# Altium schematic sheet Sheet1.SchDoc(Sheet1) (continued)

## Library data of the parts
A-GND C-GND DVDD GATE2 RESET SENV2+ SENV- USB2+ VB2DET VBUS2 VBUS_C Vout = TEST_POINT: Manufacturer: Yageo; Manufacturer Part Number: RC0402FR-07100RL; Power Rating: 62.5mW; Resistance: 100R; Temperature Coefficient: 100ppm/°C; Tolerance: 1%; Voltage Rating: 50V; Case Code (Imperial): 0402; Case Code (Metric): 1005; Case/Package: 0402; Category: Chip SMD Resistors; Component Kind: Standard; ComponentLink1Description: https://datasheet.ciiva.com/7849/1784430-7849810.pdf?src-supplier=Element14; ComponentLink1URL: https://datasheet.ciiva.com/7849/1784430-7849810.pdf?src-supplier=Element14; ComponentLink2Description: https://datasheet.ciiva.com/11808/0900766b80c66297-11808765.pdf?src-supplier=RSComponents; ComponentLink2URL: https://datasheet.ciiva.com/11808/0900766b80c66297-11808765.pdf?src-supplier=RSComponents; ComponentLink3Description: https://datasheet.ciiva.com/12667/pyu-rc0402-51-rohs-l-6-r-12667957.pdf?src-supplier=Mouser; ComponentLink3URL: https://datasheet.ciiva.com/12667/pyu-rc0402-51-rohs-l-6-r-12667957.pdf?src-supplier=Mouser; ComponentLink4Description: https://datasheet.ciiva.com/16740/pyu-rc0402-51-rohs-l-6-r-16740566.pdf?src-supplier=Future+Electronics; ComponentLink4URL: https://datasheet.ciiva.com/16740/pyu-rc0402-51-rohs-l-6-r-16740566.pdf?src-supplier=Future+Electronics; ComponentLink5Description: https://datasheet.ciiva.com/15189/getdatasheetpartid-329466-15189335.pdf?src-supplier=Verical; ComponentLink5URL: https://datasheet.ciiva.com/15189/getdatasheetpartid-329466-15189335.pdf?src-supplier=Verical; ComponentLink6Description: https://datasheet.ciiva.com/22747/1018594-22747511.pdf?src-supplier=Element14; ComponentLink6URL: https://datasheet.ciiva.com/22747/1018594-22747511.pdf?src-supplier=Element14; ComponentLink7Description: https://datasheet.ciiva.com/28367/1018594-28367226.pdf?src-supplier=Element14; ComponentLink7URL: https://datasheet.ciiva.com/28367/1018594-28367226.pdf?src-supplier=Element14; Composition: Thick Film; Depth: 0.5mm; Height: 0.32mm; Lead Free: Lead Free; Length: 1mm; Library Name: MyLibrary.SchLib; Max Operating Temperature: 155°C; Military Standard: Not; Min Operating Temperature: -55°C; Number of Pins: 2; Packaging: Tape and Reel; Pricing 1: 1=0.10000, 10=0.01500, 100=0.00620, 1000=0.00278, 2500=0.00242, 5000=0.00200 (USD); REACH SVHC: No SVHC; RoHS: Yes; Stock 1: 14920159; Supplier 1: Digi-Key; Supplier Part Number 1: 311-100LRCT-ND; Termination: SMD/SMT; Voltage Rating (DC): 50V; Width: 0.5mm
C1 C13 = CC0805CRNPO9BN1R0: CAP CER 1PF 50V C0G/NP0 0402; Manufacturer: Yageo; Dielectric: C0G; Material: Ceramic; Tolerance: 25%; Capacitance: 1pF; Case Code (Imperial): 0805; Case Code (Metric): 2012; Case/Package: 0805; Category: Ceramic Capacitors; Component Kind: Standard; ComponentLink1Description: https://datasheet.ciiva.com/144/mlccorderingcode-144977.pdf?src-supplier=Digi-Key; ComponentLink1URL: https://datasheet.ciiva.com/144/mlccorderingcode-144977.pdf?src-supplier=Digi-Key; ComponentLink2Description: https://datasheet.ciiva.com/144/mlccorderingcode-144978.pdf?src-supplier=Digi-Key; ComponentLink2URL: https://datasheet.ciiva.com/144/mlccorderingcode-144978.pdf?src-supplier=Digi-Key; ComponentLink3Description: https://datasheet.ciiva.com/144/mlccorderingcode-144979.pdf?src-supplier=Digi-Key; ComponentLink3URL: https://datasheet.ciiva.com/144/mlccorderingcode-144979.pdf?src-supplier=Digi-Key; ComponentLink4Description: https://datasheet.ciiva.com/11465/upy-gp-np0-16v-to-50v-8-11465247.pdf?src-supplier=Avnet; ComponentLink4URL: https://datasheet.ciiva.com/11465/upy-gp-np0-16v-to-50v-8-11465247.pdf?src-supplier=Avnet; Composition: Ceramic; Depth: 1.25mm; Height: 0.6mm; Lead Free: Lead Free; Length: 2mm; Library Name: MyLibrary.SchLib; Manufacturer Part Number: CC0805CRNPO9BN1R0; Max Operating Temperature: 125°C; Min Operating Temperature: -55°C; Mount: Surface Mount; Package Quantity: 1; Packaging: Tape and Reel; Pricing 1: 1=0.13000, 10=0.09300, 50=0.05260, 100=0.04360, 500=0.03056, 1000=0.02530 (USD); Radiation Hardening: No; RoHS: Yes; Stock 1: 10394; Supplier 1: Digi-Key; Supplier Part Number 1: 490-3199-1-ND; Termination: SMD/SMT; Thickness: 0.024inch; Voltage Rating: 50V; Voltage Rating (DC): 50V; Width: 0.049inch
C2 C14 C15 C23 C30 = C2012X7R1H104K085AA: CAP CER 0.1UF 16V Y5V 0402; Manufacturer: TDK Corporation; .Checked PCB: RF; .Creator: MM; Capacitance: 0.1µF; Temperature Coefficient: X7R; Tolerance: ±10%; Voltage - Rated: 50V; Applications: General Purpose; Category: Capacitors; Component Kind: Standard; ComponentLink1Description: http://product.tdk.com/en/catalog/datasheets/mlcc_commercial_general_en.pdf; ComponentLink1URL: http://product.tdk.com/en/catalog/datasheets/mlcc_commercial_general_en.pdf; Features: -; Height - Seated (Max): -; Lead Spacing: -; Lead Style: -; Library Name: MyLibrary.SchLib; Manufacturer Part Number: C2012X7R1H104K085AA; Mounting Type: Surface Mount, MLCC; Operating Temperature: -55°C ~ 125°C; Package / Case: 0805 (2012 Metric); Packaging: Cut Tape (CT); Pricing 1: 1=0.1, 10=0.066, 100=0.0303, 250=0.02476, 500=0.02118, 1000=0.0165 (USD); Ratings: -; RoHS: RoHS Compliant; Size / Dimension: 0.079" L x 0.049" W (2.00mm x 1.25mm); Stock 1: 818066; Supplier 1: Digi-Key; Supplier Part Number 1: 478-1138-1-ND; Thickness (Max): 0.037" (0.95mm); .Checked SCH: RF
C3-C9 C29 C32 = GRM21BR61A226ME44L: CAP CER 22UF 6.3V X5R 0402; Manufacturer: Murata; Dielectric Material: Ceramic; Dissipation Factor: 0.035%; Tolerance: 20%; Voltage: 10V; Capacitance: 22uF; Case Code (Imperial): 0805; Case Code (Metric): 2012; Case/Package: 0805; Category: Ceramic Capacitors; Component Kind: Standard; ComponentLink1Description: http://www.mouser.com/ds/2/281/c02e-2905.pdf?src-supplier=Mouser; ComponentLink1URL: http://www.mouser.com/ds/2/281/c02e-2905.pdf?src-supplier=Mouser; ComponentLink2Description: http://search.murata.co.jp/Ceramy/image/img/A01X/G101/ENG/GRM21BR61A226ME44-01.pdf?src-supplier=Digi-Key; ComponentLink2URL: http://search.murata.co.jp/Ceramy/image/img/A01X/G101/ENG/GRM21BR61A226ME44-01.pdf?src-supplier=Digi-Key; ComponentLink3Description: http://search.murata.co.jp/Ceramy/image/img/A01X/partnumbering_e_01.pdf?src-supplier=Digi-Key; ComponentLink3URL: http://search.murata.co.jp/Ceramy/image/img/A01X/partnumbering_e_01.pdf?src-supplier=Digi-Key; Composition: Ceramic; Depth: 1.25mm; Dielectric: X5R; Height: 1.25mm; Lead Free: Lead Free; Length: 2mm; Library Name: MyLibrary.SchLib; Manufacturer Part Number: GRM21BR61A226ME44L; Max Operating Temperature: 85°C; Min Operating Temperature: -55°C; Mount: Surface Mount; Package Quantity: 3000; Packaging: Tape and Reel; Pricing 1: 1=0.58000, 10=0.40900, 50=0.30900, 100=0.26920, 500=0.19940, 1000=0.16950 (USD); RoHS: Yes; Stock 1: 27473; Supplier 1: Digi-Key; Supplier Part Number 1: 1276-7090-1-ND; Termination: SMD/SMT; Thickness: 0.057inch; Voltage Rating: 10V; Voltage Rating (DC): 10V; Weight: 0.000335oz; Width: 1.25mm
C10 C16 C19 C21 C24-C26 = C2012X5R1E105K125AA: CAP CER 1UF 6.3V X5R 0402; Manufacturer: TDK Corporation; .Checked PCB: RF; .Creator: MM; Capacitance: 1µF; Tolerance: ±10%; Voltage - Rated: 25V; Applications: General Purpose; Category: Capacitors; Component Kind: Standard; ComponentLink1Description: http://product.tdk.com/en/catalog/datasheets/mlcc_commercial_general_en.pdf; ComponentLink1URL: http://product.tdk.com/en/catalog/datasheets/mlcc_commercial_general_en.pdf; Features: -; Height - Seated (Max): -; Lead Spacing: -; Lead Style: -; Library Name: MyLibrary.SchLib; Manufacturer Part Number: C2012X5R1E105K125AA; Mounting Type: Surface Mount, MLCC; Operating Temperature: -55°C ~ 85°C; Package / Case: 0805 (2012 Metric); Packaging: Cut Tape (CT); Pricing 1: 1=0.16, 10=0.112, 100=0.0533, 250=0.04488, 500=0.03758, 1000=0.03025 (USD); Ratings: -; RoHS: RoHS Compliant; Size / Dimension: 0.079" L x 0.049" W (2.00mm x 1.25mm); Stock 1: 57052; Supplier 1: Digi-Key; Supplier Part Number 1: 478-1249-1-ND; Temperature Coefficient: X5R; Thickness (Max): 0.057" (1.45mm); .Checked SCH: RF
C11 C12 = CC0805MKX5R5BB476: CAP CER 47UF 4V X5R 0603; Manufacturer: Yageo; Tolerance: 20%; Voltage Rating: 6.3V; Capacitance: 47uF; Case Code (Imperial): 0805; Case Code (Metric): 2012; Case/Package: 0805; Category: Ceramic Capacitors; Component Kind: Standard; ComponentLink1Description: http://components.arrow.com/part/dataview/0323_04166612?src-supplier=Arrow+Europe; ComponentLink1URL: http://components.arrow.com/part/dataview/0323_04166612?src-supplier=Arrow+Europe; ComponentLink2Description: http://www.mouser.com/ds/2/447/UPY-GPHC_X5R_4V-to-50V_25-1131599.pdf?src-supplier=Mouser; ComponentLink2URL: http://www.mouser.com/ds/2/447/UPY-GPHC_X5R_4V-to-50V_25-1131599.pdf?src-supplier=Mouser; ComponentLink3Description: http://www.yageo.com/documents/recent/UPY-GPHC_X5R_4V-to-50V_19.pdf?src-supplier=Avnet; ComponentLink3URL: http://www.yageo.com/documents/recent/UPY-GPHC_X5R_4V-to-50V_19.pdf?src-supplier=Avnet; ComponentLink4Description: http://www.yageo.com/documents/recent/UPY-GPHC_X5R_4V-to-50V_25.pdf?src-supplier=Digi-Key; ComponentLink4URL: http://www.yageo.com/documents/recent/UPY-GPHC_X5R_4V-to-50V_25.pdf?src-supplier=Digi-Key; Composition: Ceramic; Depth: 1.25mm; Dielectric: X5R; Halogen Free: Halogen Free; Lead Free: Lead Free; Length: 2mm; Library Name: MyLibrary.SchLib; Manufacturer Part Number: CC0805MKX5R5BB476; Max Operating Temperature: 85°C; Min Operating Temperature: -55°C; Mount: Surface Mount; Packaging: Tape and Reel; Pricing 1: 1=0.48000, 10=0.33700, 50=0.23560, 100=0.20190, 500=0.15144, 1000=0.12789 (USD); Radiation Hardening: No; RoHS: Yes; Stock 1: 59203; Supplier 1: Digi-Key; Supplier Part Number 1: 490-13246-1-ND; Termination: SMD/SMT; Thickness: 0.057inch; Voltage Rating (DC): 6.3V; Weight: 0.000194oz; Width: 0.049inch
C17 C18 = CC0805KRX7R9BB391: CAP CER 390PF 50V X7R 0402; Manufacturer: Yageo; Dissipation Factor: 2.5%; Insulation Resistance: 10GR; Tolerance: 10%; Capacitance: 390pF; Case Code (Imperial): 0805; Case Code (Metric): 2012; Case/Package: 0805; Category: Ceramic Capacitors; Component Kind: Standard; ComponentLink1Description: https://datasheet.ciiva.com/26918/getdatasheetpartid-403704-26918335.pdf?src-supplier=Verical; ComponentLink1URL: https://datasheet.ciiva.com/26918/getdatasheetpartid-403704-26918335.pdf?src-supplier=Verical; ComponentLink2Description: https://datasheet.ciiva.com/13264/yageo-486313-494411-13264661.pdf?src-supplier=Mouser; ComponentLink2URL: https://datasheet.ciiva.com/13264/yageo-486313-494411-13264661.pdf?src-supplier=Mouser; ComponentLink3Description: https://datasheet.ciiva.com/160/mlccorderingcode-160730.pdf?src-supplier=Digi-Key; ComponentLink3URL: https://datasheet.ciiva.com/160/mlccorderingcode-160730.pdf?src-supplier=Digi-Key; ComponentLink4Description: https://datasheet.ciiva.com/11465/upy-gphc-x7r-6-3v-to-50v-8-11465738.pdf?src-supplier=Avnet; ComponentLink4URL: https://datasheet.ciiva.com/11465/upy-gphc-x7r-6-3v-to-50v-8-11465738.pdf?src-supplier=Avnet; ComponentLink5Description: https://datasheet.ciiva.com/160/mlccorderingcode-160731.pdf?src-supplier=Digi-Key; ComponentLink5URL: https://datasheet.ciiva.com/160/mlccorderingcode-160731.pdf?src-supplier=Digi-Key; ComponentLink6Description: https://datasheet.ciiva.com/160/mlccorderingcode-160732.pdf?src-supplier=Digi-Key; ComponentLink6URL: https://datasheet.ciiva.com/160/mlccorderingcode-160732.pdf?src-supplier=Digi-Key; ComponentLink7Description: https://datasheet.ciiva.com/17436/upy-gphc-x7r-6-3v-to-50v-8-17436447.pdf?src-supplier=Avnet+Europe; ComponentLink7URL: https://datasheet.ciiva.com/17436/upy-gphc-x7r-6-3v-to-50v-8-17436447.pdf?src-supplier=Avnet+Europe; Composition: Ceramic; Depth: 1.25mm; Dielectric: X7R; Halogen Free: Halogen Free; Height: 0.6mm; Lead Free: Lead Free; Length: 2mm; Library Name: MyLibrary.SchLib; Manufacturer Part Number: CC0805KRX7R9BB391; Max Operating Temperature: 125°C; Min Operating Temperature: -55°C; Mount: Surface Mount; Package Quantity: 1; Packaging: Tape and Reel; Pricing 1: 1=0.13000, 10=0.09000, 50=0.05120, 100=0.04240, 500=0.02972, 1000=0.02461 (USD); Radiation Hardening: No; RoHS: Yes; Stock 1: 64908; Supplier 1: Digi-Key; Supplier Part Number 1: 311-1724-1-ND; Termination: SMD/SMT; Thickness: 0.024inch; Voltage Rating: 50V; Voltage Rating (DC): 50V; Weight: 0.000194oz; Width: 1.25mm
C20 C31 C34 = CC0201KRX5R8BB102: CAP CER 1000PF 16V X7R 0402; Manufacturer: Yageo; Capacitance: 1nF; Dielectric: X5R; Tolerance: 10%; Voltage Rating: 25V; Case/Package: 0201; Category: Ceramic Capacitors; Component Kind: Standard; ComponentLink1Description: http://www.yageo.com/exep/pages/download/literatures/ProductSelectionGuide%202014_Final_2.pdf?src-supplier=Digi-Key; ComponentLink1URL: http://www.yageo.com/exep/pages/download/literatures/ProductSelectionGuide%202014_Final_2.pdf?src-supplier=Digi-Key; ComponentLink2Description: http://www.yageo.com/documents/recent/UPY-GPHC_X5R_4V-to-50V_25.pdf?src-supplier=Digi-Key; ComponentLink2URL: http://www.yageo.com/documents/recent/UPY-GPHC_X5R_4V-to-50V_25.pdf?src-supplier=Digi-Key; Halogen Free: Halogen Free; Lead Free: Lead Free; Length: 0.024inch; Library Name: MyLibrary.SchLib; Manufacturer Part Number: CC0201KRX5R8BB102; Max Operating Temperature: 85°C; Min Operating Temperature: -55°C; Mount: Surface Mount; Packaging: Tape and Reel; Pricing 1: 1=0.10000, 10=0.01500, 50=0.00800, 100=0.00660, 500=0.00470, 1000=0.00369, 2500=0.00336, 5000=0.00309 (USD); RoHS: Yes; Stock 1: 54569; Supplier 1: Digi-Key; Supplier Part Number 1: 311-1352-1-ND; Thickness: 0.013inch; Voltage Rating (DC): 25V; Width: 0.012inch
C22 = C0805C223K5RACTU: CAP CER 0.22UF 6.3V X5R 0402; Manufacturer: KEMET; Capacitance: 22nF; Dielectric: X7R; Dielectric Material: Ceramic; Tolerance: 10%; Voltage: 50V; Case/Package: 0805; Category: Ceramic Capacitors; Component Kind: Standard; ComponentLink1Description: https://datasheet.ciiva.com/18193/getdatasheetpartid-385695-18193669.pdf?src-supplier=Verical; ComponentLink1URL: https://datasheet.ciiva.com/18193/getdatasheetpartid-385695-18193669.pdf?src-supplier=Verical; Depth: 1.25mm; Height: 0.78mm; Length: 2mm; Library Name: MyLibrary.SchLib; Manufacturer Part Number: C0805C223K5RACTU; Max Operating Temperature: 125°C; Min Operating Temperature: -55°C; Mount: Surface Mount; Packaging: Tape and Reel; Pricing 1: 1=0.10000, 10=0.05700, 50=0.03120, 100=0.02550, 500=0.01822, 1000=0.01431 (USD); Radiation Hardening: No; RoHS: Yes; Stock 1: 230380; Supplier 1: Digi-Key; Supplier Part Number 1: 490-3252-1-ND; Voltage Rating (DC): 50V
C27 C28 = C0603C103M5RACTU: CAP CER 0.01UF 16V X7R 0402; Manufacturer: KEMET; Capacitance: 10nF; Dielectric Material: Ceramic; Dissipation Factor: 2.5%; Tolerance: 20%; Voltage Rating: 50V; Case Code (Imperial): 0603; Case Code (Metric): 1608; Case/Package: 0603; Category: Ceramic Capacitors; Component Kind: Standard; ComponentLink1Description: https://datasheet.ciiva.com/7823/kem-c1002-x7r-smd-7823979.pdf?src-supplier=Element14; ComponentLink1URL: https://datasheet.ciiva.com/7823/kem-c1002-x7r-smd-7823979.pdf?src-supplier=Element14; ComponentLink2Description: https://datasheet.ciiva.com/7823/kem-c1002-x7r-smd-7823980.pdf?src-supplier=Element14; ComponentLink2URL: https://datasheet.ciiva.com/7823/kem-c1002-x7r-smd-7823980.pdf?src-supplier=Element14; Depth: 0.8mm; Dielectric: X7R; Height: 0.8mm; Lead Pitch: 0.7mm; Length: 1.6mm; Library Name: MyLibrary.SchLib; Manufacturer Part Number: C0603C103M5RACTU; Max Operating Temperature: 125°C; Min Operating Temperature: -55°C; Mount: Surface Mount,PCB; Number of Pins: 2; Package Quantity: 1; Packaging: Tape and Reel; Pricing 1: 1=0.10000, 10=0.03300, 50=0.01820, 100=0.01480, 500=0.01058, 1000=0.00832 (USD); Radiation Hardening: No; REACH SVHC: No SVHC; RoHS: Yes; Stock 1: 479692; Supplier 1: Digi-Key; Supplier Part Number 1: 399-17617-1-ND; Termination: SMD/SMT; Thickness: 0.034inch; Voltage Rating (DC): 50V; Width: 0.8mm
C33 = C2012X5R1C106M: CAP CER 10UF 4V X5R 0402; Manufacturer: TDK Corporation; Manufacturer Part Number: C2012X5R1C106M/1.25; .Checked PCB: used; Capacitance: 10µF; Tolerance: ±20%; .Checked SCH: used; Applications: General Purpose; Category: Capacitors; Component Kind: Standard; ComponentLink1Description: http://www.tdk.com/pdf/general_B11.pdf; ComponentLink1URL: http://www.tdk.com/pdf/general_B11.pdf; Features: -; Height - Seated (Max): -; Lead Spacing: -; Lead Style: -; Library Name: MyLibrary.SchLib; Mounting Type: Surface Mount, MLCC; Operating Temperature: -55°C ~ 85°C; Package / Case: 0805 (2012 Metric); Packaging: Cut Tape (CT); Pricing 1: 1=0.45, 10=0.357, 100=0.215, 250=0.16124, 500=0.1376, 1000=0.1075 (USD); Ratings: -; RoHS: RoHS Compliant; Size / Dimension: 0.079" L x 0.049" W (2.00mm x 1.25mm); Stock 1: 66161; Supplier 1: Digi-Key; Supplier Part Number 1: 490-10693-1-ND; Temperature Coefficient: X5R; Thickness (Max): 0.039" (1.00mm)
D1 D2 = SZMM5Z5V1T1G: DIODE ZENER Single 5.1V 6% 200mW 2-Pin SOD-523 T/R; Manufacturer: ON Semiconductor; ESD Protection: Yes; Forward Voltage: 900mV; Impedance: 60R; Max Reverse Leakage Current: 2uA; Test Current: 5mA; Case/Package: SOD-523-2; Category: Zener Diodes; Component Kind: Standard; ComponentLink1Description: https://datasheet.ciiva.com/16492/mm5z2v4t1-d-16492709.pdf?src-supplier=Future+Electronics; ComponentLink1URL: https://datasheet.ciiva.com/16492/mm5z2v4t1-d-16492709.pdf?src-supplier=Future+Electronics; ComponentLink2Description: https://datasheet.ciiva.com/10638/mm5z2v4t1-d-10638122.pdf?src-supplier=Avnet; ComponentLink2URL: https://datasheet.ciiva.com/10638/mm5z2v4t1-d-10638122.pdf?src-supplier=Avnet; Contact Plating: Tin,Matte; Element Configuration: Single; Height: 0.5mm; Lead Free: Lead Free; Length: 1.3mm; Library Name: MyLibrary.SchLib; Manufacturer Part Number: SZMM5Z5V1T1G; Max Operating Temperature: 150°C; Max Power Dissipation: 200mW; Min Operating Temperature: -65°C; Number of Pins: 2; Package Quantity: 3000; Packaging: Tape and Reel; Peak Reverse Current: 2uA; Power Dissipation: 200mW; Pricing 1: 1=0.38000, 10=0.24500, 100=0.13650, 500=0.09100, 1000=0.06300 (USD); Radiation Hardening: No; RoHS: Yes; Stock 1: 1144; Supplier 1: Digi-Key; Supplier Part Number 1: SZMM5Z5V1T1GOSCT-ND; Tolerance: 6%; Width: 0.9mm; Working Voltage: 5.1V; Zener Voltage: 5.1V
D3 = BAT54CLT1G: DIODE SCHOTTKY 30V 0.2A 3-Pin SOT-23 T/R; Manufacturer: ON Semiconductor; Average Rectified Current: 200mA; Capacitance: 10pF; Element Configuration: Common Cathode; Forward Voltage: 800mV; Max Forward Surge Current (Ifsm): 600mA; Max Power Dissipation: 225mW; Max Reverse Leakage Current: 2uA; Recovery Time: 5ns; Case/Package: SOT-23-3; Category: Schottky Diodes; Component Kind: Standard; ComponentLink10Description: https://datasheet.ciiva.com/28655/bat54clt1-d-28655787.pdf?src-supplier=Element14; ComponentLink10URL: https://datasheet.ciiva.com/28655/bat54clt1-d-28655787.pdf?src-supplier=Element14; ComponentLink11Description: https://datasheet.ciiva.com/8294/bat54clt1-d-8294495.pdf?src-supplier=Avnet; ComponentLink11URL: https://datasheet.ciiva.com/8294/bat54clt1-d-8294495.pdf?src-supplier=Avnet; ComponentLink1Description: https://datasheet.ciiva.com/1240/bat54clt1-d-1240707.pdf?src-supplier=Newark; ComponentLink1URL: https://datasheet.ciiva.com/1240/bat54clt1-d-1240707.pdf?src-supplier=Newark; ComponentLink2Description: https://datasheet.ciiva.com/34301/885769-34301654.pdf?src-supplier=Jameco; ComponentLink2URL: https://datasheet.ciiva.com/34301/885769-34301654.pdf?src-supplier=Jameco; ComponentLink3Description: https://datasheet.ciiva.com/30479/0900766b8121b932-30479128.pdf?src-supplier=RSComponents; ComponentLink3URL: https://datasheet.ciiva.com/30479/0900766b8121b932-30479128.pdf?src-supplier=RSComponents; ComponentLink4Description: https://datasheet.ciiva.com/15144/getdatasheetpartid-98336-15144809.pdf?src-supplier=Verical; ComponentLink4URL: https://datasheet.ciiva.com/15144/getdatasheetpartid-98336-15144809.pdf?src-supplier=Verical; ComponentLink5Description: https://datasheet.ciiva.com/22659/1918740-22659014.pdf?src-supplier=Element14; ComponentLink5URL: https://datasheet.ciiva.com/22659/1918740-22659014.pdf?src-supplier=Element14; ComponentLink6Description: https://datasheet.ciiva.com/1180/bat54clt1-d-1180247.pdf?src-supplier=Element14; ComponentLink6URL: https://datasheet.ciiva.com/1180/bat54clt1-d-1180247.pdf?src-supplier=Element14; ComponentLink7Description: https://datasheet.ciiva.com/1180/bat54clt1-d-1180248.pdf?src-supplier=Element14; ComponentLink7URL: https://datasheet.ciiva.com/1180/bat54clt1-d-1180248.pdf?src-supplier=Element14; ComponentLink8Description: https://datasheet.ciiva.com/16205/bat54clt1-d-16205033.pdf?src-supplier=Future+Electronics; ComponentLink8URL: https://datasheet.ciiva.com/16205/bat54clt1-d-16205033.pdf?src-supplier=Future+Electronics; ComponentLink9Description: https://datasheet.ciiva.com/905/bat54clt1-d-905835.pdf?src-supplier=Digi-Key; ComponentLink9URL: https://datasheet.ciiva.com/905/bat54clt1-d-905835.pdf?src-supplier=Digi-Key; Contact Plating: Tin,Matte; Current Rating: 200mA; Forward Current: 200mA; Halogen Free: Halogen Free; Height: 1.01mm; Lead Free: Lead Free; Length: 3.04mm; Library Name: MyLibrary.SchLib; Manufacturer Part Number: BAT54CLT1G; Max Operating Temperature: 125°C; Max Repetitive Reverse Voltage (Vrrm): 30V; Max Reverse Voltage (DC): 30V; Max Surge Current: 600mA; Min Operating Temperature: -55°C; Number of Pins: 3; Package Quantity: 3000; Packaging: Tape and Reel; Peak Non-Repetitive Surge Current: 600mA; Peak Reverse Current: 2uA; Power Dissipation: 225mW; Pricing 1: 1=0.13000, 10=0.11800, 100=0.05550, 500=0.03290, 1000=0.02162 (USD); Radiation Hardening: No; REACH SVHC: No SVHC; Reverse Recovery Time: 5ns; RoHS: Yes; Stock 1: 292991; Supplier 1: Digi-Key; Supplier Part Number 1: BAT54CLT1GOSCT-ND; Voltage Rating (DC): 30V; Width: 1.4mm
D4 D9 = BAT54T1G: DIODE SCHOTTKY 30V 600 mA - SOD-123 SMD; Manufacturer: ON Semiconductor; Average Rectified Current: 200mA; Capacitance: 10pF; Element Configuration: Single; Forward Voltage: 350mV; Max Forward Surge Current (Ifsm): 600mA; Max Reverse Leakage Current: 2uA; Polarity: Standard; Power Dissipation: 400mW; Recovery Time: 5ns; Case/Package: SOD-123-2; Category: Schottky Diodes; Component Kind: Standard; ComponentLink10Description: https://datasheet.ciiva.com/915/bat54t1-d-915484.pdf?src-supplier=Digi-Key; ComponentLink10URL: https://datasheet.ciiva.com/915/bat54t1-d-915484.pdf?src-supplier=Digi-Key; ComponentLink1Description: https://datasheet.ciiva.com/915/bat54t1-d-915482.pdf?src-supplier=Farnell; ComponentLink1URL: https://datasheet.ciiva.com/915/bat54t1-d-915482.pdf?src-supplier=Farnell; ComponentLink2Description: https://datasheet.ciiva.com/915/bat54t1-d-915482.pdf?src-supplier=Digi-Key; ComponentLink2URL: https://datasheet.ciiva.com/915/bat54t1-d-915482.pdf?src-supplier=Digi-Key; ComponentLink3Description: https://datasheet.ciiva.com/4714/bat54t1-d-108887-4714899.pdf?src-supplier=Mouser; ComponentLink3URL: https://datasheet.ciiva.com/4714/bat54t1-d-108887-4714899.pdf?src-supplier=Mouser; ComponentLink4Description: https://datasheet.ciiva.com/15144/getdatasheetpartid-39113-15144061.pdf?src-supplier=Verical; ComponentLink4URL: https://datasheet.ciiva.com/15144/getdatasheetpartid-39113-15144061.pdf?src-supplier=Verical; ComponentLink5Description: https://datasheet.ciiva.com/1180/bat54t1-d-1180308.pdf?src-supplier=Element14; ComponentLink5URL: https://datasheet.ciiva.com/1180/bat54t1-d-1180308.pdf?src-supplier=Element14; ComponentLink6Description: https://datasheet.ciiva.com/8299/bat54t1-d-8299989.pdf?src-supplier=Avnet; ComponentLink6URL: https://datasheet.ciiva.com/8299/bat54t1-d-8299989.pdf?src-supplier=Avnet; ComponentLink7Description: https://datasheet.ciiva.com/16475/bat54t1-d-16475623.pdf?src-supplier=Avnet+Asia; ComponentLink7URL: https://datasheet.ciiva.com/16475/bat54t1-d-16475623.pdf?src-supplier=Avnet+Asia; ComponentLink8Description: https://datasheet.ciiva.com/25950/bat54t1-d-25950154.pdf?src-supplier=Avnet+Europe; ComponentLink8URL: https://datasheet.ciiva.com/25950/bat54t1-d-25950154.pdf?src-supplier=Avnet+Europe; ComponentLink9Description: https://datasheet.ciiva.com/915/bat54t1-d-915483.pdf?src-supplier=Digi-Key; ComponentLink9URL: https://datasheet.ciiva.com/915/bat54t1-d-915483.pdf?src-supplier=Digi-Key; Contact Plating: Tin,Matte; Current Rating: 200mA; Forward Current: 200mA; Height: 1.12mm; Lead Free: Lead Free; Length: 2.69mm; Library Name: MyLibrary.SchLib; Manufacturer Part Number: BAT54T1G; Max Operating Temperature: 125°C; Max Repetitive Reverse Voltage (Vrrm): 30V; Max Reverse Voltage (DC): 30V; Max Surge Current: 600mA; Min Operating Temperature: -55°C; Number of Pins: 2; Output Current: 200mA; Package Quantity: 3000; Packaging: Tape and Reel; Peak Non-Repetitive Surge Current: 600mA; Peak Reverse Current: 2uA; Pricing 1: 1=0.25000, 10=0.17600, 100=0.09410, 500=0.05390, 1000=0.04508 (USD); Radiation Hardening: No; REACH SVHC: No SVHC; Reverse Recovery Time: 5ns; RoHS: Yes; Stock 1: 58282; Supplier 1: Digi-Key; Supplier Part Number 1: BAT54T1GOSCT-ND; Termination: SMD/SMT; Voltage Rating (DC): 30V; Width: 1.6mm
D5-D8 = LG L29K-G2J1-24-Z: LED SMARTLED GREEN 570NM 0603; Manufacturer: OSRAM Opto Semiconductors Inc; .Checked PCB: used; Current - Limit (Min): 600mA; Current - Output: 500mA; Current - Test: 2mA; Lens Style/Size: Rectangle with Flat Top, 1.3mm x 0.8mm; Lens Type: Diffused; Luminous Flux @ Current - Test: 12 mlm; Millicandela Rating: 3.92mcd; Number of Regulators: 1; Regulator Topology: Positive Fixed; Viewing Angle: 160°; Voltage - Dropout (Typical): 0.16V @ 500mA; Voltage - Forward (Vf) Typ: 1.7V; Voltage - Input: 2.6 V ~ 6 V; Voltage - Output: 2.5V; Wavelength - Peak: 572nm; .Checked SCH: used; Category: Optoelectronics; Color: Green; Component Kind: Standard; ComponentLink1Description: http://catalog.osram-os.com/catalogue/catalogue.do;jsessionid=32CB61882C089EDD4B6D0A54E7E1F117?act=downloadFile&favOid=020000020000138e000100b6; ComponentLink1URL: http://catalog.osram-os.com/catalogue/catalogue.do;jsessionid=32CB61882C089EDD4B6D0A54E7E1F117?act=downloadFile&favOid=020000020000138e000100b6; ComponentLink2Description: http://www.micrel.com/_PDF/mic5353.pdf; ComponentLink2URL: http://www.micrel.com/_PDF/mic5353.pdf; Family: LEDs - <75mA, Discre; Height: 0.65mm; Library Name: MyLibrary.SchLib; Manufacturer Part Number: LG L29K-G2J1-24-Z; Mounting Type: Surface Mount; Operating Temperature: -40°C ~ 125°C; Package / Case: 0603 (1608 Metric); Packaging: Cut Tape (CT); Price 1k [$]: 0.08744; Pricing 1: 1=0.16, 10=0.137, 100=0.1093, 250=0.09564, 500=0.08744 (USD); RoHS: RoHS Compliant; Size / Dimension: 1.70mm L x 0.80mm W; Stock 1: 30318; Supplier: Digikey; Supplier 1: Digi-Key; Supplier Device Package: 6-TMLF® (1.6x1.6); Supplier Part Number: 475-2709-1-ND; Supplier Part Number 1: 475-2709-1-ND; Value: Green; Wavelength - Dominant: 570nm
FB1 FB2 = BLM21PG300SN1D: FERRITE CHIP BEAD 30 OHM SMD  100MHz 4A 14mOhm DCR 0805 T/R; Manufacturer: Murata; DC Current: 3A; DC Resistance (DCR): 14mR; Impedance: 30R; Material: Steel,Ferrite; Number of Circuits: 1; Resistance: 15mR; Shielding: Unshielded; Tolerance: 25%; Case Code (Imperial): 0805; Case Code (Metric): 2012; Case/Package: 0805; Category: Ferrite Beads and Chips; Component Kind: Standard; ComponentLink10Description: https://datasheet.ciiva.com/41449/001172365-da-01-en-drossel-0805-30r0-2-0x1-25mm-4000st-41449606.pdf?src-supplier=Conrad; ComponentLink10URL: https://datasheet.ciiva.com/41449/001172365-da-01-en-drossel-0805-30r0-2-0x1-25mm-4000st-41449606.pdf?src-supplier=Conrad; ComponentLink1Description: https://datasheet.ciiva.com/11795/0900766b80021a8e-11795584.pdf?src-supplier=RSComponents; ComponentLink1URL: https://datasheet.ciiva.com/11795/0900766b80021a8e-11795584.pdf?src-supplier=RSComponents; ComponentLink2Description: https://datasheet.ciiva.com/19460/1845339-19460237.pdf?src-supplier=Jameco; ComponentLink2URL: https://datasheet.ciiva.com/19460/1845339-19460237.pdf?src-supplier=Jameco; ComponentLink3Description: https://datasheet.ciiva.com/6212/l0110s0100blm21p-6212358.pdf?src-supplier=Digi-Key; ComponentLink3URL: https://datasheet.ciiva.com/6212/l0110s0100blm21p-6212358.pdf?src-supplier=Digi-Key; ComponentLink4Description: https://datasheet.ciiva.com/25903/l0110s0100blm21p-25903510.pdf?src-supplier=Avnet+Europe; ComponentLink4URL: https://datasheet.ciiva.com/25903/l0110s0100blm21p-25903510.pdf?src-supplier=Avnet+Europe; ComponentLink5Description: https://datasheet.ciiva.com/6212/l0110s0100blm21p-6212360.pdf?src-supplier=Digi-Key; ComponentLink5URL: https://datasheet.ciiva.com/6212/l0110s0100blm21p-6212360.pdf?src-supplier=Digi-Key; ComponentLink6Description: https://datasheet.ciiva.com/3081/l0110s0100blm21p-3081657.pdf?src-supplier=Avnet; ComponentLink6URL: https://datasheet.ciiva.com/3081/l0110s0100blm21p-3081657.pdf?src-supplier=Avnet; ComponentLink7Description: https://datasheet.ciiva.com/16199/l0110s0100blm21p-16199625.pdf?src-supplier=Future+Electronics; ComponentLink7URL: https://datasheet.ciiva.com/16199/l0110s0100blm21p-16199625.pdf?src-supplier=Future+Electronics; ComponentLink8Description: https://datasheet.ciiva.com/3081/l0110s0100blm21p-3081658.pdf?src-supplier=Avnet; ComponentLink8URL: https://datasheet.ciiva.com/3081/l0110s0100blm21p-3081658.pdf?src-supplier=Avnet; ComponentLink9Description: https://datasheet.ciiva.com/8021/1756152-8021599.pdf?src-supplier=Element14; ComponentLink9URL: https://datasheet.ciiva.com/8021/1756152-8021599.pdf?src-supplier=Element14; Core Material: Ferrite; Current Rating: 4A; Depth: 1.25mm; Frequency: 100MHz; Height: 0.85mm; Lead Free: Lead Free; Length: 2mm; Library Name: MyLibrary.SchLib; Manufacturer Part Number: BLM21PG300SN1D; Max Current Rating: 4A; Max DC Current: 3A; Max Operating Temperature: 125°C; Military Standard: Not; Min Operating Temperature: -55°C; Number of Lines: 1; Number of Pins: 2; Package Quantity: 1; Packaging: Tape and Reel; Pricing 1: 1=0.11000, 10=0.09300, 25=0.07240, 50=0.06000, 100=0.05170, 250=0.04136, 500=0.03722, 1000=0.03102 (USD); Radiation Hardening: No; REACH SVHC: No SVHC; RoHS: Yes; Stock 1: 43451; Supplier 1: Digi-Key; Supplier Part Number 1: 490-1052-1-ND; Termination: SMD/SMT; Test Frequency: 100MHz; Width: 1.25mm
FID1 FID3 FID4 = FID_1MMC: Fiducial 40mil Diameter, 120mil Mask; Library Name: 28Pins_Project_V1I1.SCHLIB; Supplier: Digikey
J3 = 2129691-1: CONN USB TYPE-C_ USB 3.1 _USB 3.1 Gen 2, Superspeed+_ Receptacle Connector 24 Position Surface Mount, Right Angle; Through Hole; MF: JAE Electronics; MP: DX07S024JJ2R1300; Availability: Unavailable; Component Kind: Standard; Library Name: MyLibrary.SchLib; Package: None; Price: None; SnapEDA_Link: https://www.snapeda.com/parts/DX07S024JJ2R1300/JAE%20Electronics/view-part/473419/?ref=snap; Supplier 1: Digi-Key; Supplier Part Number 1: A122008CT-ND
J4 = IL-G-3P-S3T2-SA: CONN HEADER VERT 3POS 2.5MM; Manufacturer: JAE Electronics; Connector Type: Male Pin; Current Rating: 3A; Number of Positions: 3; Pitch: 0.098inch; Voltage Rating: 1.5kV; Category: Connectors; Color: Brown; Component Kind: Standard; ComponentLink1Description: https://datasheet.ciiva.com/5153/il-g-4503-5153575.pdf?src-supplier=Mouser; ComponentLink1URL: https://datasheet.ciiva.com/5153/il-g-4503-5153575.pdf?src-supplier=Mouser; ComponentLink2Description: https://datasheet.ciiva.com/738/2008-71-il-g-738706.pdf?src-supplier=Digi-Key; ComponentLink2URL: https://datasheet.ciiva.com/738/2008-71-il-g-738706.pdf?src-supplier=Digi-Key; Contact Gender: Male; Contact Material: Brass; Contact Mating Length: 0.157inch; Contact Plating: Tin; Fastening Type: Friction; Housing Material: Nylon; Lead Free: Lead Free; Library Name: MyLibrary.SchLib; Manufacturer Part Number: IL-G-3P-S3T2-SA; Mount: Through Hole; Number of Rows: 1; Package Quantity: 200; Packaging: Bulk; Pricing 1: 1=0.44000, 10=0.42000, 100=0.29400, 500=0.25200, 1000=0.21000, 5000=0.18000, 10000=0.16800 (USD); RoHS: Yes; Stock 1: 2358; Supplier 1: Digi-Key; Supplier Part Number 1: 670-2541-ND; Termination: Solder
J5 = 67643-0910: CONN USB TYPE A 4POS R/A; MF: Molex; Availability: Unavailable; Component Kind: Standard; Library Name: MyLibrary.SchLib; MP: 67643-0910; Package: None; Price: None; SnapEDA_Link: https://www.snapeda.com/parts/67643-0910/Molex/view-part/287255/?ref=snap; Supplier 1: Digi-Key; Supplier Part Number 1: WM4993-ND
JP1 = 68000-103HLF: CONN HEADER 3POS .100 STR 15AU; Manufacturer: FCI; .Checked PCB: used; .Creator: MM; Connector Type: Header, Unshrouded; Number of Positions: 3; Number of Positions Loaded: All; Pitch: 0.100" (2.54mm); .Checked SCH: used; Category: Connectors, Interconnects; Color: Black; Component Kind: Standard; ComponentLink1Description: http://portal.fciconnect.com/Comergent//fci/drawing/68000.pdf; ComponentLink1URL: http://portal.fciconnect.com/Comergent//fci/drawing/68000.pdf; Contact Finish: Gold or Gold, GXT™; Contact Finish Thickness: 30µin (0.76µm); Contact Mating Length: 0.230" (5.84mm); Contact Type: Male Pin; Fastening Type: -; Features: -; Library Name: MyLibrary.SchLib; Manufacturer Part Number: 68000-103HLF; Mounting Type: Through Hole; Number of Rows: 1; Packaging: Bulk; Pricing 1: 1=0.15, 10=0.145, 25=0.108, 50=0.0924 (USD); RoHS: RoHS Compliant; Row Spacing: -; Stock 1: 37214; Supplier 1: Digi-Key; Supplier Part Number 1: 609-3461-ND; Termination: Solder
L1 = ETQ-P6F4R6HFA: FIXED IND 4.6UH 9.3A 6.48 MOHM; Manufacturer: Panasonic; Core Material: Metal,Composite; Current: 16A; DC Resistance (DCR): 6.48mR; Inductance Tolerance: 25%; Insulation Resistance: 10MR; Saturation Current: 6.2A; Shielding: Unshielded; Test Frequency: 100kHz; Case/Package: SMD/SMT; Category: Fixed Inductors; Component Kind: Standard; ComponentLink1Description: https://datasheet.ciiva.com/11799/0900766b80befd5f-11799896.pdf?src-supplier=RSComponents; ComponentLink1URL: https://datasheet.ciiva.com/11799/0900766b80befd5f-11799896.pdf?src-supplier=RSComponents; ComponentLink2Description: https://datasheet.ciiva.com/6057/1672243-6057434.pdf?src-supplier=Farnell; ComponentLink2URL: https://datasheet.ciiva.com/6057/1672243-6057434.pdf?src-supplier=Farnell; ComponentLink3Description: https://datasheet.ciiva.com/43484/34083-43484636.pdf?src-supplier=Farnell; ComponentLink3URL: https://datasheet.ciiva.com/43484/34083-43484636.pdf?src-supplier=Farnell; ComponentLink4Description: https://datasheet.ciiva.com/43485/1672243-43485382.pdf?src-supplier=Farnell; ComponentLink4URL: https://datasheet.ciiva.com/43485/1672243-43485382.pdf?src-supplier=Farnell; ComponentLink5Description: https://datasheet.ciiva.com/46376/1672243-46376630.pdf?src-supplier=Element14; ComponentLink5URL: https://datasheet.ciiva.com/46376/1672243-46376630.pdf?src-supplier=Element14; ComponentLink6Description: https://datasheet.ciiva.com/46388/34083-46388345.pdf?src-supplier=Element14; ComponentLink6URL: https://datasheet.ciiva.com/46388/34083-46388345.pdf?src-supplier=Element14; ComponentLink7Description: https://datasheet.ciiva.com/46388/1672243-46388346.pdf?src-supplier=Element14; ComponentLink7URL: https://datasheet.ciiva.com/46388/1672243-46388346.pdf?src-supplier=Element14; ComponentLink8Description: https://datasheet.ciiva.com/16940/70068940-16940747.pdf?src-supplier=Allied+Electronics; ComponentLink8URL: https://datasheet.ciiva.com/16940/70068940-16940747.pdf?src-supplier=Allied+Electronics; ComponentLink9Description: https://datasheet.ciiva.com/30153/2agl0000ce1-30153437.pdf?src-supplier=Onlinecomponents.com; ComponentLink9URL: https://datasheet.ciiva.com/30153/2agl0000ce1-30153437.pdf?src-supplier=Onlinecomponents.com; Current Rating: 9.3A; DC Current: 9.3A; Depth: 12.8mm; Height: 5.7mm; Inductance: 4.6uH; Lead Free: Lead Free; Length: 12.5mm; Library Name: MyLibrary.SchLib; Manufacturer Part Number: ETQ-P6F4R6HFA; Max DC Current: 9.3A; Max Operating Temperature: 150°C; Military Standard: Not; Min Operating Temperature: -40°C; Mount: Surface Mount; Package Quantity: 500; Packaging: Tape and Reel; Pricing 1: 1=4.08000, 3=2.72000, 10=2.04000 (USD); REACH SVHC: No SVHC; RMS Current (Irms): 9.3A; RoHS: Yes; Stock 1: 88; Supplier 1: Quest; Supplier Part Number 1: ETQ-P6F4R6HFA; Termination: SMD/SMT; Tolerance: 25%; Width: 12.5mm
L2 = SRN8040-1R0Y: FIXED IND 1UH 7.8A 10 MOHM SMD; Manufacturer: Bourns; Core Material: Ferrite; DC Resistance (DCR): 10mR; Inductance Tolerance: 30%; Saturation Current: 11A; Self Resonant Frequency: 75MHz; Shielding: Shielded; Test Frequency: 100kHz; Case/Package: Nonstandard; Category: Fixed Inductors; Component Kind: Standard; ComponentLink1Description: https://datasheet.ciiva.com/7848/1790247-7848058.pdf?src-supplier=Element14; ComponentLink1URL: https://datasheet.ciiva.com/7848/1790247-7848058.pdf?src-supplier=Element14; ComponentLink2Description: https://datasheet.ciiva.com/11802/0900766b80fe1f3f-11802607.pdf?src-supplier=RSComponents; ComponentLink2URL: https://datasheet.ciiva.com/11802/0900766b80fe1f3f-11802607.pdf?src-supplier=RSComponents; ComponentLink3Description: https://datasheet.ciiva.com/1049/srn8040-1049447.pdf?src-supplier=Digi-Key; ComponentLink3URL: https://datasheet.ciiva.com/1049/srn8040-1049447.pdf?src-supplier=Digi-Key; ComponentLink4Description: https://datasheet.ciiva.com/1049/srn8040-1049448.pdf?src-supplier=Digi-Key; ComponentLink4URL: https://datasheet.ciiva.com/1049/srn8040-1049448.pdf?src-supplier=Digi-Key; ComponentLink5Description: https://datasheet.ciiva.com/1049/srn8040-1049449.pdf?src-supplier=Digi-Key; ComponentLink5URL: https://datasheet.ciiva.com/1049/srn8040-1049449.pdf?src-supplier=Digi-Key; ComponentLink6Description: https://datasheet.ciiva.com/7847/1865628-7847913.pdf?src-supplier=Element14; ComponentLink6URL: https://datasheet.ciiva.com/7847/1865628-7847913.pdf?src-supplier=Element14; ComponentLink7Description: https://datasheet.ciiva.com/16941/70231668-16941130.pdf?src-supplier=Allied+Electronics; ComponentLink7URL: https://datasheet.ciiva.com/16941/70231668-16941130.pdf?src-supplier=Allied+Electronics; Composition: Wirewound; Current Rating: 7.8A; DC Current: 7.8A; Depth: 8.3mm; Height: 4mm; Height - Seated (Max): 0.157inch; Inductance: 1uH; Length: 8mm; Library Name: MyLibrary.SchLib; Manufacturer Part Number: SRN8040-1R0Y; Max DC Current: 7.8A; Max Operating Temperature: 125°C; Military Standard: Not; Min Operating Temperature: -40°C; Mount: Surface Mount; Number of Pins: 2; Package Quantity: 1000; Packaging: Tape and Reel; Pricing 1: 1=0.72000, 10=0.63100, 25=0.58560, 50=0.49560, 100=0.45050, 250=0.42348, 500=0.37842 (USD); REACH SVHC: No SVHC; RMS Current (Irms): 7.8A; RoHS: Yes; Stock 1: 2862; Supplier 1: Digi-Key; Supplier Part Number 1: SRN8040-1R0YCT-ND; Termination: SMD/SMT; Tolerance: 30%; Width: 8mm
MH2 MH3 = MH_7.4MMC_3.2MMD: Mounting Hole 7.4mm Diameter, 3.2mm Drill; .Creator: MM; Library Name: 28Pins_Project_V1I1.SCHLIB
MH4 = MH_7.4MMNC_3.2MMD: Mounting Hole 7.4mm Diameter (Non Copper), 3.2mm Drill NPTH; Library Name: 28Pins_Project_V1I1.SCHLIB
P+ P- = PAD_WITH_HOLE_4MMx1.5MM: CONN HEADER 1POS .100 STR 15AU; Library Name: MyLibrary.SchLib
Q1-Q3 Q6 = ECH8310-TL-H: MOSFET Tranistor P-CH 30V 9A 8-Pin ECH T/R; Manufacturer: ON Semiconductor; Drain to Source Resistance: 17mR; Element Configuration: Single; Fall Time: 87ns; Gate to Source Voltage (Vgs): 20V; Input Capacitance: 1.4nF; Max Power Dissipation: 1.5W; Rise Time: 45ns; Turn-Off Delay Time: 134ns; Turn-On Delay Time: 10ns; Category: MOSFETs; Component Kind: Standard; ComponentLink1Description: https://datasheet.ciiva.com/13052/ech8310-d-72318-13052832.pdf?src-supplier=Mouser; ComponentLink1URL: https://datasheet.ciiva.com/13052/ech8310-d-72318-13052832.pdf?src-supplier=Mouser; ComponentLink2Description: https://datasheet.ciiva.com/30479/0900766b8127c97b-30479731.pdf?src-supplier=RSComponents; ComponentLink2URL: https://datasheet.ciiva.com/30479/0900766b8127c97b-30479731.pdf?src-supplier=RSComponents; ComponentLink3Description: https://datasheet.ciiva.com/6371/ech8310-d-6371820.pdf?src-supplier=Digi-Key; ComponentLink3URL: https://datasheet.ciiva.com/6371/ech8310-d-6371820.pdf?src-supplier=Digi-Key; ComponentLink4Description: https://datasheet.ciiva.com/11164/ech8310-d-11164927.pdf?src-supplier=Avnet; ComponentLink4URL: https://datasheet.ciiva.com/11164/ech8310-d-11164927.pdf?src-supplier=Avnet; Continuous Drain Current (ID): 9A; Drain to Source Voltage (Vdss): 30V; Halogen Free: Halogen Free; Height: 0.9mm; Lead Free: Lead Free; Length: 2.9mm; Library Name: MyLibrary.SchLib; Manufacturer Part Number: ECH8310-TL-H; Max Operating Temperature: 150°C; Min Operating Temperature: -55°C; Number of Pins: 8; Package Quantity: 3000; Packaging: Tape and Reel; Pricing 1: 1=0.68000, 10=0.59400, 25=0.55800, 100=0.40500, 500=0.33840, 1000=0.28800 (USD); Radiation Hardening: No; Rds On Max: 17mR; RoHS: Yes; Stock 1: 2258; Supplier 1: Digi-Key; Supplier Part Number 1: ECH8310-TL-HOSCT-ND; Width: 2.3mm
Q4 Q5 = NTTFS4H05NTAG: MOSFET Transistor N−Channel Single  Power  25V, 94A, 3.3mΩ; Manufacturer: ON Semiconductor; Input Capacitance: 1.205nF; Max Power Dissipation: 2.66W; Rds On Max: 3.3mR; Category: MOSFETs; Component Kind: Standard; ComponentLink1Description: http://www.mouser.com/ds/2/308/NTTFS4H05N-D-463776.pdf?src-supplier=Mouser; ComponentLink1URL: http://www.mouser.com/ds/2/308/NTTFS4H05N-D-463776.pdf?src-supplier=Mouser; ComponentLink2Description: http://www.onsemi.com/pub/Collateral/NTTFS4H05N-D.PDF?src-supplier=Digi-Key; ComponentLink2URL: http://www.onsemi.com/pub/Collateral/NTTFS4H05N-D.PDF?src-supplier=Digi-Key; ComponentLink3Description: http://www.onsemi.com/pub/Collateral/NTTFS4H05N-D.PDF?src-supplier=Avnet; ComponentLink3URL: http://www.onsemi.com/pub/Collateral/NTTFS4H05N-D.PDF?src-supplier=Avnet; Continuous Drain Current (ID): 94A; Drain to Source Voltage (Vdss): 25V; Lead Free: Lead Free; Library Name: MyLibrary.SchLib; Manufacturer Part Number: NTTFS4H05NTAG; Mount: Surface Mount; Number of Pins: 8; Package Quantity: 1500; Packaging: Tape and Reel; Pricing 1: 1=0.60000, 25=0.59000, 100=0.57000, 500=0.56000, 1000=0.55000 (USD); RoHS: Yes; Stock 1: 1500; Supplier 1: Rochester Electronics; Supplier Part Number 1: NTTFS4H05NTAG
R1 R3 R22 R44 = RC0805FR-07100KL: RES SMD 100K OHM 1% 1/16W 0402; Manufacturer: Yageo; .Checked PCB: RF; .Creator: MM; Power (Watts): 0.125W, 1/8W; Temperature Coefficient: ±100ppm/°C; Category: Resistors; Component Kind: Standard; ComponentLink1Description: http://www.yageo.com/documents/recent/PYu-RC_Group_51_RoHS_L_1.pdf; ComponentLink1URL: http://www.yageo.com/documents/recent/PYu-RC_Group_51_RoHS_L_1.pdf; Composition: Thick Film; Features: Moisture Resistant; Height: 0.024" (0.60mm); Library Name: MyLibrary.SchLib; Manufacturer Part Number: RC0805FR-07100KL; Number of Terminations: 2; Operating Temperature: -55°C ~ 155°C; Package / Case: 0805 (2012 Metric); Packaging: Cut Tape (CT); Pricing 1: 1=0.1, 10=0.021, 25=0.0148, 100=0.0084, 250=0.0064, 500=0.0051, 1000=0.00376, 2500=0.00326 (USD); Resistance (Ohms): 100k; RoHS: RoHS Compliant; Size / Dimension: 0.079" L x 0.049" W (2.00mm x 1.25mm); Stock 1: 1604881; Supplier 1: Digi-Key; Supplier Device Package: 0805; Supplier Part Number 1: 311-100KLRCT-ND; Tolerance: ±1%; .Checked SCH: RF
R2 R37 = CPF0603B510KE1: RES SMD 510K OHM 5% 1/16W 0402; Manufacturer: TE Connectivity Neohm; Number of Resistors: 1; Power Rating: 63mW; Resistance: 510kR; Temperature Coefficient: 25ppm/°C; Tolerance: 0.1%; Voltage Rating: 50V; Case Code (Imperial): 0603; Case Code (Metric): 1608; Case/Package: 0603; Category: Chip SMD Resistors; Component Kind: Standard; ComponentLink1Description: https://datasheet.ciiva.com/22670/1723857-22670911.pdf?src-supplier=Element14; ComponentLink1URL: https://datasheet.ciiva.com/22670/1723857-22670911.pdf?src-supplier=Element14; Composition: Thin Film; ELV: Compliant; Height: 0.45mm; Length: 1.55mm; Library Name: MyLibrary.SchLib; Manufacturer Part Number: CPF0603B510KE1; Max Operating Temperature: 155°C; Min Operating Temperature: -55°C; Mount: Surface Mount; Number of Terminations: 2; Package Quantity: 1000; Packaging: Tape and Reel; Pricing 1: 1=0.62000, 10=0.44600, 100=0.23210 (USD); REACH SVHC: No SVHC; RoHS: Yes; Stock 1: 3932; Supplier 1: Digi-Key; Supplier Part Number 1: YAG3305CT-ND; Termination: Solder; Voltage Rating (DC): 50V; Weight: 7.1E-05oz; Width: 0.8mm
R4 R5 = RC0805JR-070RL: RES SMD 0 OHM JUMPER 1/16W 0402; Manufacturer: Yageo; .Checked PCB: RF; Power (Watts): 0.125W, 1/8W; Resistance (Ohms): 0.0; Category: Resistors; Component Kind: Standard; ComponentLink1Description: http://www.yageo.com/documents/recent/PYu-RC0805_51_RoHS_L_4.pdf; ComponentLink1URL: http://www.yageo.com/documents/recent/PYu-RC0805_51_RoHS_L_4.pdf; Composition: Thick Film; Features: -; Height: 0.024" (0.60mm); Library Name: MyLibrary.SchLib; Manufacturer Part Number: RC0805JR-070RL; Number of Terminations: 2; Package / Case: 0805 (2012 Metric); Packaging: Cut Tape (CT); Price 1k [$]: 0.01479; Pricing 1: 10=0.017, 50=0.0126, 100=0.0096, 250=0.00716, 500=0.00528, 1000=0.00352 (USD); RoHS: RoHS Compliant; Size / Dimension: 0.079" L x 0.049" W (2.00mm x 1.25mm); Stock 1: 933202; Supplier: Digikey; Supplier 1: Digi-Key; Supplier Part Number: 311-0.0ARCT-ND; Supplier Part Number 1: 311-0.0JRCT-ND; Temperature Coefficient: -; Tolerance: Jumper; Value: 0R; .Checked SCH: RF
R6 R7 R15 R16 = CSRF0603FT10L0: RES 0.01 OHM 1% 1/5W 0402; Manufacturer: Stackpole Electronics; Max Power Dissipation: 250mW; Resistance: 10mR; Temperature Coefficient: 75ppm/°C; Case Code (Imperial): 0603; Case Code (Metric): 1608; Case/Package: 0603; Category: Chip SMD Resistors; Component Kind: Standard; ComponentLink1Description: http://components.arrow.com/part/dataview/62280733S793104N1174?src-supplier=Arrow+Electronics; ComponentLink1URL: http://components.arrow.com/part/dataview/62280733S793104N1174?src-supplier=Arrow+Electronics; ComponentLink2Description: https://www.seielect.com/Catalog/SEI-csrf.pdf?src-supplier=Digi-Key; ComponentLink2URL: https://www.seielect.com/Catalog/SEI-csrf.pdf?src-supplier=Digi-Key; ComponentLink3Description: https://www.seielect.com/catalog/SEI-Packaging.pdf?src-supplier=Digi-Key; ComponentLink3URL: https://www.seielect.com/catalog/SEI-Packaging.pdf?src-supplier=Digi-Key; Composition: Metal Foil; Depth: 0.8mm; Features: Moisture Resistant,Automotive AEC-Q200; Height: 0.03inch; Lead Free: Lead Free; Length: 0.063inch; Library Name: MyLibrary.SchLib; Manufacturer Part Number: CSRF0603FT10L0; Max Operating Temperature: 170°C; Military Standard: Not; Min Operating Temperature: -55°C; Mount: Surface Mount; Number of Terminations: 2; Packaging: Tape and Reel; Pricing 1: 1=0.61000, 10=0.45100, 25=0.36560, 50=0.31800, 100=0.26240, 250=0.22792, 500=0.18550, 1000=0.14713 (USD); RoHS: Yes; Stock 1: 95306; Supplier 1: Digi-Key; Supplier Part Number 1: P19181CT-ND; Tolerance: 1%; Width: 0.031inch
R8 R9 = CRGCQ0805F680R: RES SMD 680 OHM 1% 1/16W 0402 SMD; Manufacturer: TE Connectivity; Category: Chip SMD Resistors; Component Kind: Standard; ComponentLink1Description: https://4donline.ihs.com/images/VipMasterIC/IC/TYEL/TYEL-S-A0004929575/TYEL-S-A0004929575-1.pdf?hkey=52A5661711E402568146F3353EA87419&src-supplier=Farnell; ComponentLink1URL: https://4donline.ihs.com/images/VipMasterIC/IC/TYEL/TYEL-S-A0004929575/TYEL-S-A0004929575-1.pdf?hkey=52A5661711E402568146F3353EA87419&src-supplier=Farnell; Library Name: MyLibrary.SchLib; Manufacturer Part Number: CRGCQ0805F680R; Pricing 1: 1=0.10000, 10=0.04700, 100=0.01890, 1000=0.00851, 2500=0.00738 (USD); RoHS: No; Stock 1: 37512; Supplier 1: Digi-Key; Supplier Part Number 1: A129625CT-ND
R10 R18 R20 R21 R23 R30 R31 R33 = RC0402FR-07100RL: RES SMD 100 OHM 1% 1/16W 0402; Component Kind: Standard; Library Name: MyLibrary.SchLib
R11 R12 = RC0805FR-071KL: RES SMD 1K OHM 1% 1/16W 0402; Manufacturer: Yageo; .Checked PCB: RF; .Creator: MM; Power (Watts): 0.125W, 1/8W; Temperature Coefficient: ±100ppm/°C; Category: Resistors; Component Kind: Standard; ComponentLink1Description: http://www.yageo.com/documents/recent/PYu-RC_Group_51_RoHS_L_1.pdf; ComponentLink1URL: http://www.yageo.com/documents/recent/PYu-RC_Group_51_RoHS_L_1.pdf; Composition: Thick Film; Features: Moisture Resistant; Height: 0.024" (0.60mm); Library Name: MyLibrary.SchLib; Manufacturer Part Number: RC0805FR-071KL; Number of Terminations: 2; Operating Temperature: -55°C ~ 155°C; Package / Case: 0805 (2012 Metric); Packaging: Cut Tape (CT); Pricing 1: 1=0.1, 10=0.021, 25=0.0148, 100=0.0084, 250=0.0064, 500=0.0051, 1000=0.00376, 2500=0.00326 (USD); Resistance (Ohms): 1k; RoHS: RoHS Compliant; Size / Dimension: 0.079" L x 0.049" W (2.00mm x 1.25mm); Stock 1: 3497185; Supplier 1: Digi-Key; Supplier Device Package: 0805; Supplier Part Number 1: 311-1.00KLRCT-ND; Tolerance: ±1%; .Checked SCH: RF
R13 R19 R35 R36 = RC0805FR-0710KL: RES SMD 10K OHM 1% 1/16W 0402; Manufacturer: Yageo; .Checked PCB: RF; .Creator: MM; Power (Watts): 0.125W, 1/8W; Temperature Coefficient: ±100ppm/°C; Category: Resistors; Component Kind: Standard; ComponentLink1Description: http://www.yageo.com/documents/recent/PYu-RC_Group_51_RoHS_L_1.pdf; ComponentLink1URL: http://www.yageo.com/documents/recent/PYu-RC_Group_51_RoHS_L_1.pdf; Composition: Thick Film; Features: Moisture Resistant; Height: 0.024" (0.60mm); Library Name: MyLibrary.SchLib; Manufacturer Part Number: RC0805FR-0710KL; Number of Terminations: 2; Operating Temperature: -55°C ~ 155°C; Package / Case: 0805 (2012 Metric); Packaging: Cut Tape (CT); Pricing 1: 1=0.1, 10=0.021, 25=0.0148, 100=0.0084, 250=0.0064, 500=0.0051, 1000=0.00376, 2500=0.00326 (USD); Resistance (Ohms): 10k; RoHS: RoHS Compliant; Size / Dimension: 0.079" L x 0.049" W (2.00mm x 1.25mm); Stock 1: 4094384; Supplier 1: Digi-Key; Supplier Device Package: 0805; Supplier Part Number 1: 311-10.0KLRCT-ND; Tolerance: ±1%; .Checked SCH: RF
R14 R25 = WSL0603R0200FEA18: RES 0.02 OHM 1% 1/16W 0402; Manufacturer: Vishay Dale; Max Power Dissipation: 200mW; Power Rating: 100mW; Temperature Coefficient: 75ppm/°C; Case Code (Imperial): 0603; Case Code (Metric): 1608; Case/Package: 0603; Category: Chip SMD Resistors; Component Kind: Standard; ComponentLink1Description: https://datasheet.ciiva.com/9031/wslhigh-9031045.pdf?src-supplier=Avnet; ComponentLink1URL: https://datasheet.ciiva.com/9031/wslhigh-9031045.pdf?src-supplier=Avnet; ComponentLink2Description: https://datasheet.ciiva.com/28684/1911169-28684602.pdf?src-supplier=Element14; ComponentLink2URL: https://datasheet.ciiva.com/28684/1911169-28684602.pdf?src-supplier=Element14; ComponentLink3Description: https://datasheet.ciiva.com/2483/wslhigh-2483819.pdf?src-supplier=Digi-Key; ComponentLink3URL: https://datasheet.ciiva.com/2483/wslhigh-2483819.pdf?src-supplier=Digi-Key; ComponentLink4Description: https://datasheet.ciiva.com/2483/wslhigh-2483820.pdf?src-supplier=Digi-Key; ComponentLink4URL: https://datasheet.ciiva.com/2483/wslhigh-2483820.pdf?src-supplier=Digi-Key; ComponentLink5Description: https://datasheet.ciiva.com/2483/wslhigh-2483821.pdf?src-supplier=Digi-Key; ComponentLink5URL: https://datasheet.ciiva.com/2483/wslhigh-2483821.pdf?src-supplier=Digi-Key; Composition: Metal Strip,Metal Element; Contact Plating: Tin,Matte; Depth: 0.76mm; Features: Moisture Resistant; Height: 0.018inch; Lead Free: Lead Free; Length: 0.06inch; Library Name: MyLibrary.SchLib; Manufacturer Part Number: WSL0603R0200FEA18; Max Operating Temperature: 170°C; Military Standard: Not; Min Operating Temperature: -65°C; Number of Terminations: 2; Package Quantity: 1; Packaging: Tape and Reel; Pricing 1: 1=0.96000, 10=0.85400, 25=0.78560, 50=0.71660, 100=0.62010, 250=0.52364, 500=0.44096, 1000=0.37482 (USD); Radiation Hardening: No; REACH SVHC: Unknown; Resistance: 20mR; RoHS: Yes; Stock 1: 20646; Supplier 1: Digi-Key; Supplier Part Number 1: YAG6081CT-ND; Termination: SMD/SMT; Tolerance: 1%; Width: 0.8mm
